# Altium schematic sheet IFRR.SchDoc
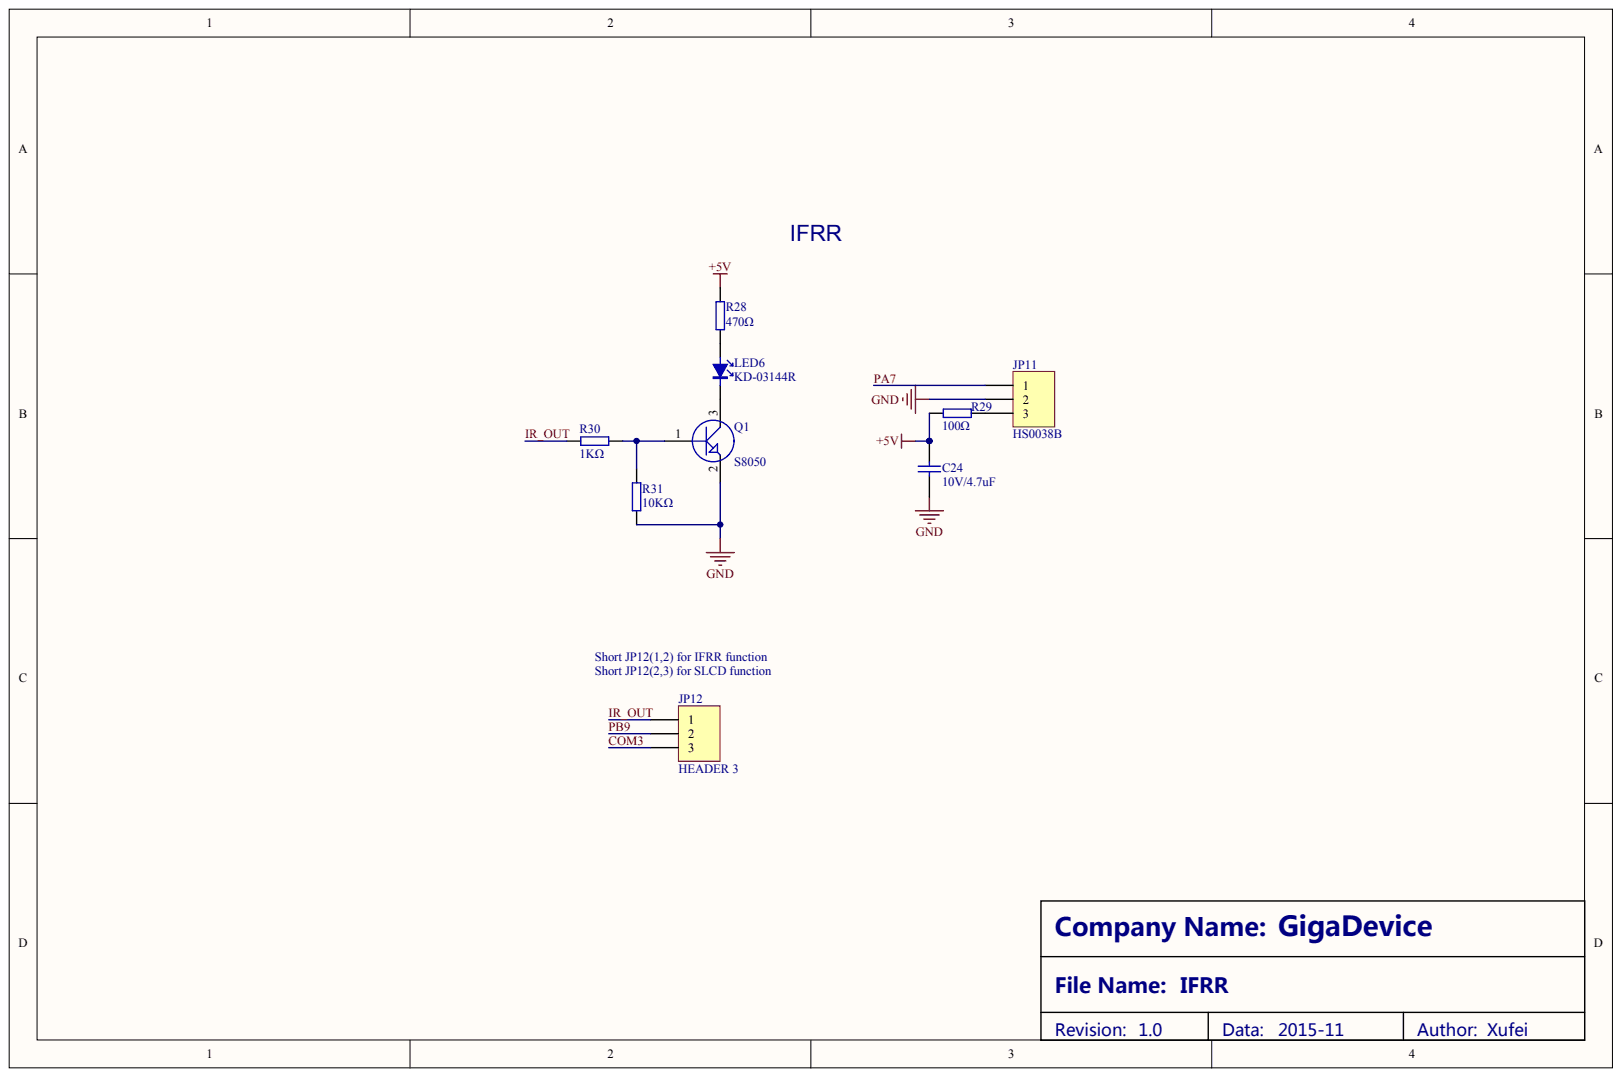
[diagram p0: IFRR.SchDoc]

## Parts
C24  10V/4.7uF
JP11  HS0038B
JP12  HEADER 3
LED6  KD-03144R
Q1  S8050
R28  470Ω
R29  100Ω
R30  1KΩ
R31  10KΩ

## Nets
+5V: C24.2 R28.2 R29.1
GND: C24.1 JP11.2 Q1.2 R31.1
COM3: JP12.3
IR_OUT: JP12.1 R30.1
PA7: JP11.1
PB9: JP12.2
NetJP11_3: JP11.3 R29.2
NetLED6_1: LED6.1 R28.1
NetLED6_2: LED6.2 Q1.3
NetQ1_1: Q1.1 R30.2 R31.2
